# Altium schematic sheet power_side.SchDoc(power_side)
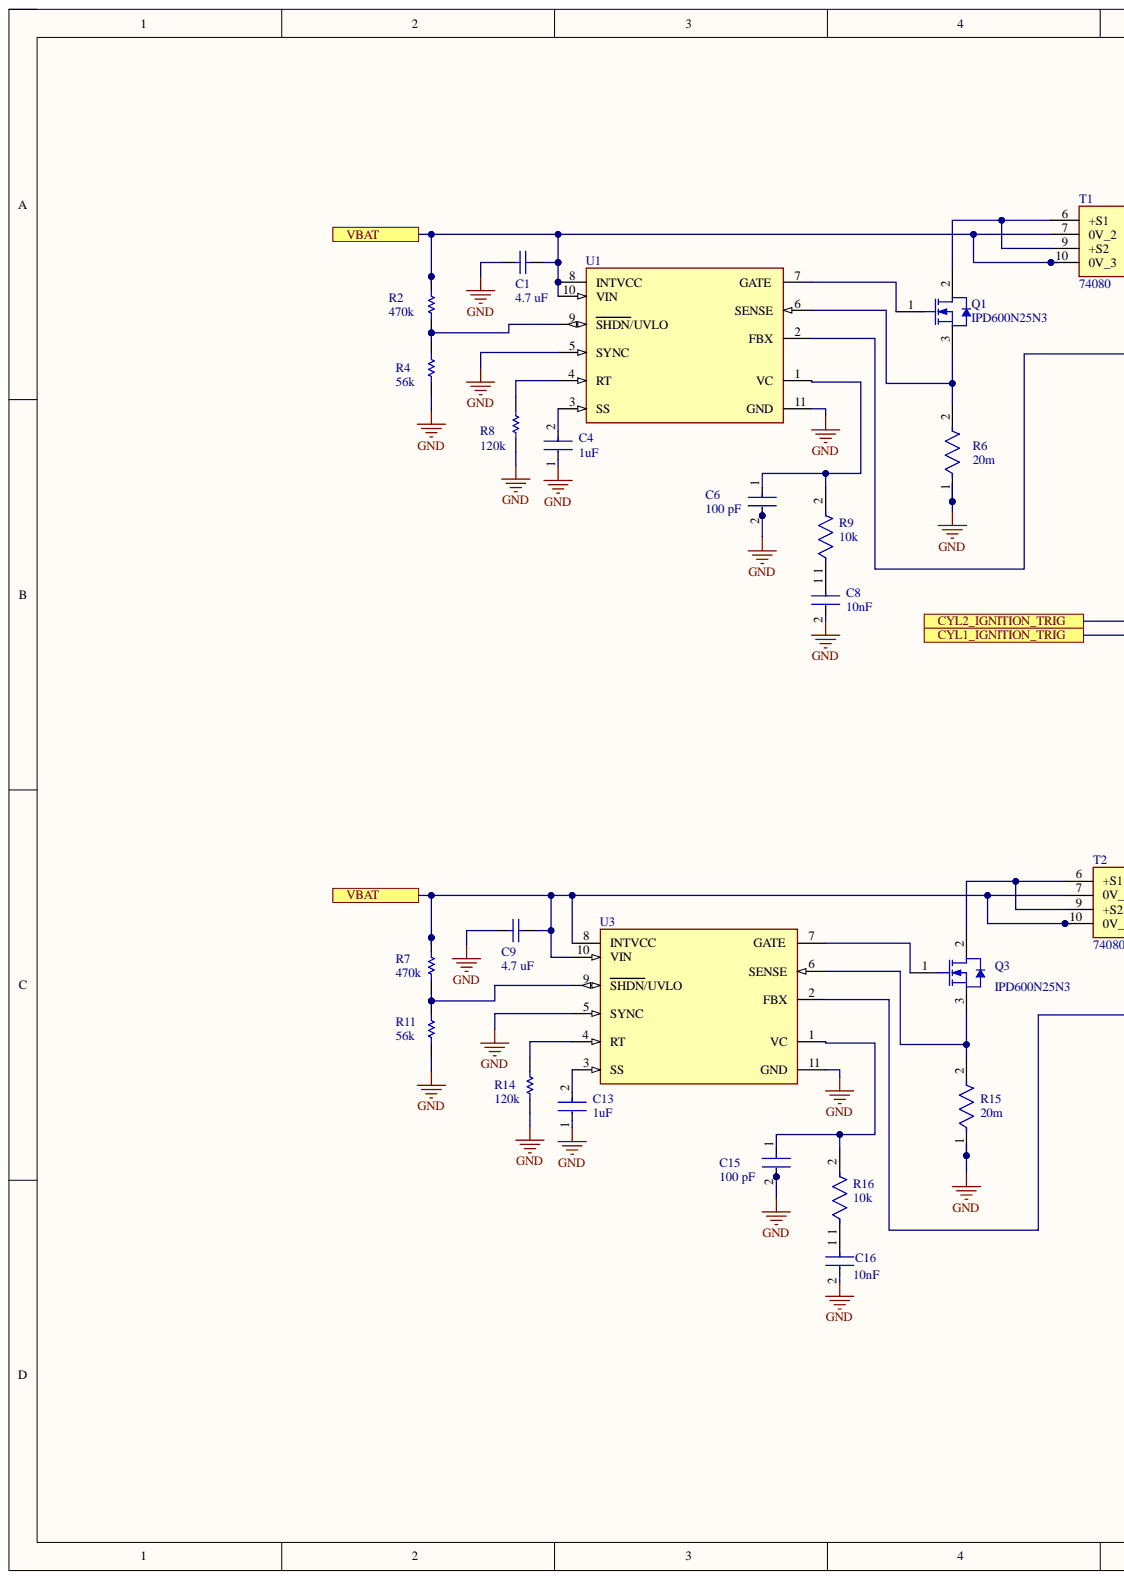
[diagram p0: power_side.SchDoc, left]
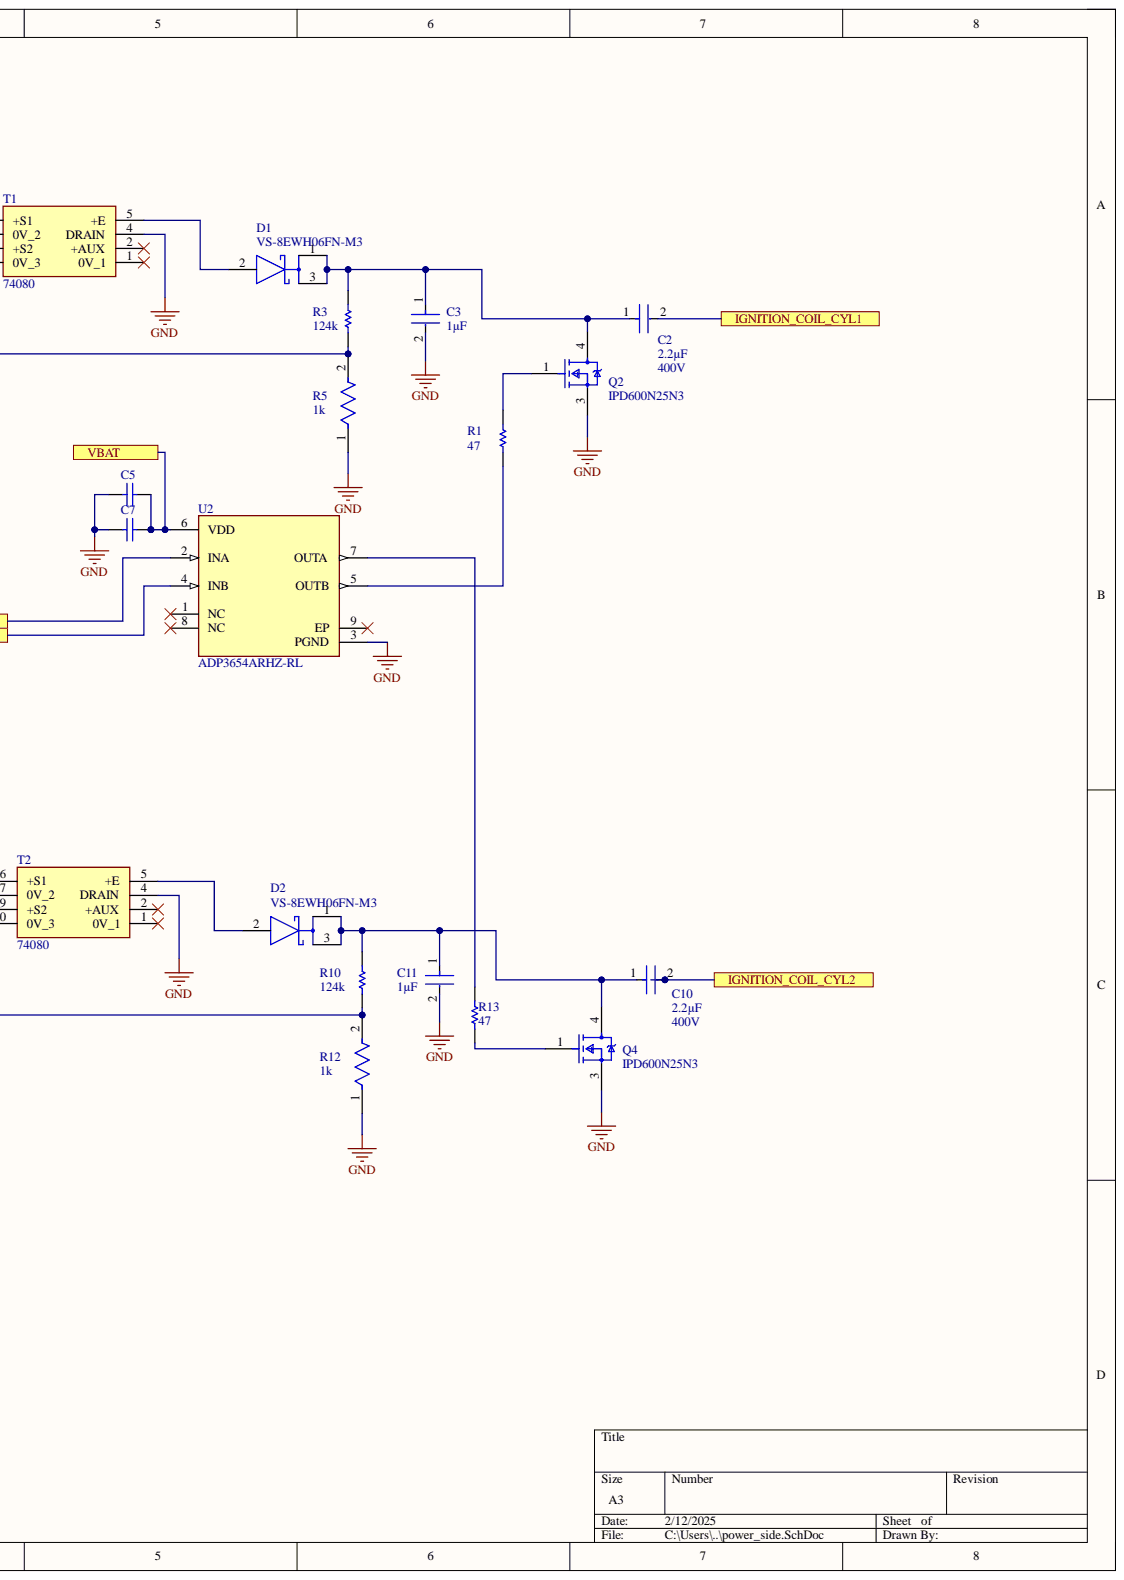
[diagram p1: power_side.SchDoc, right]

## Parts
C1  GRM21BR61C475KA88L  [CAPC2013X135X45LL10T25]  (value not drawn)
C2  Capacitance: 2.2µF  Voltage Rating (AC): 400V  [FP-BFC233820225-MFG]  (hidden comment: BFC233820225)
C3  Capacitance: 1µF  [FP-B32672Z4105K000-MFG]  (hidden comment: B32672Z4105K000)
C4  Capacitance: 1uF  [FP-C1608-080-0_1-IPC_B]  (hidden comment: C1608X5R1H105K080AB)
C5  06035C104KAT4A  [CAPC1608X90X35LL15T15]  (value not drawn)
C6  value 100 pF  Capacitance: 100 pF  [FP-CC0603-DA-MFG]  (hidden comment: CC0603KRX7R9BB101)
C7  C0603C475K8PACTU  [CAPC1608X87X35NL15T15]  (value not drawn)
C8  Capacitance: 10nF  [FP-0603-L_1_6_0_15-W_0_81-MFG]  (hidden comment: 06035C103KAT2A)
C9  Capacitance: 4.7 uF  [CAPC2013X135X45LL10T25]  (hidden comment: GRM21BR61C475KA88L)
C10  Capacitance: 2.2µF  Voltage Rating (AC): 400V  [FP-BFC233820225-MFG]  (hidden comment: BFC233820225)
C11  Capacitance: 1µF  [FP-B32672Z4105K000-MFG]  (hidden comment: B32672Z4105K000)
C13  Capacitance: 1uF  [FP-C1608-080-0_1-IPC_B]  (hidden comment: C1608X5R1H105K080AB)
C15  value 100 pF  Capacitance: 100 pF  [FP-CC0603-DA-MFG]  (hidden comment: CC0603KRX7R9BB101)
C16  Capacitance: 10nF  [FP-0603-L_1_6_0_15-W_0_81-MFG]  (hidden comment: 06035C103KAT2A)
D1  PN: VS-8EWH06FN-M3  [FP-TO-252-2_v2-MFG]  (hidden comment: C3D03060E)
D2  PN: VS-8EWH06FN-M3  [FP-TO-252-2_v2-MFG]  (hidden comment: C3D03060E)
Q1  IPD600N25N3  [INF-PG-TO252-3-11_A_L]
Q2  IPD600N25N3  [FP-PG-TO252-3-MFG]
Q3  IPD600N25N3  [INF-PG-TO252-3-11_A_L]
Q4  IPD600N25N3  [FP-PG-TO252-3-MFG]
R1  value 47  [RESC1609X50X30LL10T20]  (hidden comment: CRCW060347R0FKEA)
R2  value 470k  [RESC1608X55X25ML10T15]  (hidden comment: RC0603FR-07470KL)
R3  value 124k  [RESC1608X55X30LL15T15]  (hidden comment: ERJ-3EKF1243V)
R4  value 56k  [RESC1608X55X25LL10T15]  (hidden comment: RC0603FR-0756KL)
R5  value 1k  [FP-RC0603-0_55-IPC_C]  (hidden comment: RC0603FR-071KL)
R6  value 20m  [FP-CRA2512-MFG]  (hidden comment: CRA2512-FZ-R020ELF)
R7  value 470k  [RESC1608X55X25ML10T15]  (hidden comment: RC0603FR-07470KL)
R8  value 120k  [RESC1608X55X25NL10T15]  (hidden comment: RC0603FR-07120KL)
R9  Resistance: 10k  [FP-RC0603-0_55-IPC_C]  (hidden comment: RC0603FR-0710KL)
R10  value 124k  [RESC1608X55X30LL15T15]  (hidden comment: ERJ-3EKF1243V)
R11  Resistance: 56k  [RESC1608X55X25LL10T15]  (hidden comment: RC0603FR-0756KL)
R12  value 1k  [FP-RC0603-0_55-IPC_C]  (hidden comment: RC0603FR-071KL)
R13  value 47  [RESC1609X50X30LL10T20]  (hidden comment: CRCW060347R0FKEA)
R14  value 120k  [RESC1608X55X25NL10T15]  (hidden comment: RC0603FR-07120KL)
R15  value 20m  [FP-CRA2512-MFG]  (hidden comment: CRA2512-FZ-R020ELF)
R16  Resistance: 10k  [FP-RC0603-0_55-IPC_C]  (hidden comment: RC0603FR-0710KL)
T1  74080  [74080]
T2  74080  [74080]
U1  LT3758EDD#PBF  [FP-DD-10-05-08-1699-IPC_B]  (value not drawn)
U2  ADP3654ARHZ-RL  [FP-RH-8-1-IPC_C]
U3  LT3758EDD#PBF  [FP-DD-10-05-08-1699-IPC_B]  (value not drawn)

## Ports
CYL1_IGNITION_TRIG, CYL2_IGNITION_TRIG, IGNITION_COIL_CYL1, IGNITION_COIL_CYL2, VBAT

## Nets
GND: C1.1 C3.2 C4.1 C5.2 C6.2 C7.1 C8.2 C9.1 C11.2 C13.1 C15.2 C16.2 Q2.3 Q4.3 R4.1 R5.1 R6.1 R8.1 R11.1 R12.1 R14.1 R15.1 T1.4(DRAIN) T2.4(DRAIN) U1.5(SYNC) U1.11(GND) U2.3(PGND) U3.5(SYNC) U3.11(GND)
CYL1_IGNITION_TRIG: U2.4(INB) ; port CYL1_IGNITION_TRIG
CYL2_IGNITION_TRIG: U2.2(INA) ; port CYL2_IGNITION_TRIG
IGNITION_COIL_CYL1: C2.2 ; port IGNITION_COIL_CYL1
IGNITION_COIL_CYL2: C10.2 ; port IGNITION_COIL_CYL2
VBAT: C1.2 C5.1 C7.2 C9.2 R2.2 R7.2 T1.7(0V_2) T1.10(0V_3) T2.7(0V_2) T2.10(0V_3) U1.8(INTVCC) U1.10(VIN) U2.6(VDD) U3.8(INTVCC) U3.10(VIN) ; port VBAT
NetC2_1: C2.1 C3.1 D1.1 D1.3 Q2.4 R3.2
NetC4_2: C4.2 U1.3(SS)
NetC6_1: C6.1 R9.2 U1.1(VC)
NetC8_1: C8.1 R9.1
NetC10_1: C10.1 C11.1 D2.1 D2.3 Q4.4 R10.2
NetC13_2: C13.2 U3.3(SS)
NetC15_1: C15.1 R16.2 U3.1(VC)
NetC16_1: C16.1 R16.1
NetD1_2: D1.2 T1.5(+E)
NetD2_2: D2.2 T2.5(+E)
NetQ1_1: Q1.1 U1.7(GATE)
NetQ1_2: Q1.2 T1.6(+S1) T1.9(+S2)
NetQ1_3: Q1.3 R6.2 U1.6(SENSE)
NetQ2_1: Q2.1 R1.2
NetQ3_1: Q3.1 U3.7(GATE)
NetQ3_2: Q3.2 T2.6(+S1) T2.9(+S2)
NetQ3_3: Q3.3 R15.2 U3.6(SENSE)
NetQ4_1: Q4.1 R13.1
NetR1_1: R1.1 U2.5(OUTB)
NetR2_1: R2.1 R4.2 U1.9(SHDN/UVLO)
NetR3_1: R3.1 R5.2 U1.2(FBX)
NetR7_1: R7.1 R11.2 U3.9(SHDN/UVLO)
NetR8_2: R8.2 U1.4(RT)
NetR10_1: R10.1 R12.2 U3.2(FBX)
NetR13_2: R13.2 U2.7(OUTA)
NetR14_2: R14.2 U3.4(RT)

Not connected: T1 1(0V_1) 2(+AUX); T2 1(0V_1) 2(+AUX); U2 1(NC) 8(NC) 9(EP)

## Library data of the parts
C1 = CMP-1662-00015-2: Chip Capacitor, 4.7 uF, +/- 10%, 16 V, -55 to 85 degC, 0805 (2012 Metric), RoHS, Tape and Reel; Capacitance: 4.7 uF; Case\/Package: 0805; Type (Capacitors): X5R; Voltage Rating: 16 V; Case Code (Imperial): 0805; Case Code (Metric): 2012; Component Kind: Standard; ComponentLink1Description: Manufacturer URL; ComponentLink1URL: http://www.murata.com/; ComponentLink2Description: Datasheet; ComponentLink2URL: http://search.murata.co.jp/Ceramy/image/img/A01X/G101/ENG/GRM21BR61C475KA88-01.pdf; DatasheetVersion: 03/2016; Height: 1.25 mm; Length: 2 mm; Library Name: Altium Content Vault; Max Operating Temperature: 85 degC; Min Operating Temperature: -55 degC; Mount: Surface Mount; Mounting Technology: Surface Mount; Package Quantity: 3000; PackageDescription: 2-Pin Surface Mount Device, Body 2 x 1.25 mm; PackageReference: 0805; Packaging: Tape and Reel; PartNumber: GRM21BR61C475KA88L; Pins: 2; Radiation Hardening: No; REACH SVHC: No SVHC; RoHSCompliant: true; Tolerance: 10%; Voltage Rating (DC): 16 V; Width: 1.25 mm
C2 C10 = CMP-00030-39758189-1: BFC233820225 Film Capacitor, Polypropylene, 800V, 20% +Tol, 20% -Tol, 0.22uF, Through Hole Mount; Dielectric: PP; Insulation Resistance: 11GΩ; Lead Diameter: 800µm; Voltage Rating: 630V; Case/Package: Radial; Component Kind: Standard; Contact Plating: Tin; Depth: 15mm; Diameter: 18mm; Dielectric Material: PP; Height: 28mm; Height - Seated (Max): 24.9936mm; Lead Free: Lead Free; Lead Pitch: 27.5mm; Length: 31.5mm; Library Name: Altium Content Vault; Max Operating Temperature: 110°C; Min Operating Temperature: -55°C; Mount: Through Hole; NexarPartId: 39758189; Number of Pins: 2; Packaging: Bulk; Radiation Hardening: No; REACH SVHC: Unknown; RoHS: Compliant; Schedule B: 8532250020, 8532250020; Termination: Radial; Tolerance: 20%; Voltage Rating (DC): 630V; Width: 15.0114mm
C3 C11 = CMP-00131-19048049-1: B32672Z4105K000 Film Capacitor, Polypropylene, 450V, 10% +Tol, 10% -Tol, 1uF, Through Hole Mount, 7143; Dielectric: PP; Lead Diameter: 800µm; Voltage Rating (AC): 220V; Case/Package: Radial; Component Kind: Standard; Depth: 11mm; Dielectric Material: Polypropylene; Features: General Purpose; Height: 18.5mm; Height - Seated (Max): 18.4912mm; Lead Pitch: 15mm; Length: 18mm; Library Name: Altium Content Vault; Lifecycle Status: Production; Max Length: 18mm; Max Operating Temperature: 125°C; Max Width: 11mm; Min Operating Temperature: -55°C; Mount: Through Hole; NexarPartId: 19048049; Packaging: Bulk; Radiation Hardening: No; REACH SVHC: No SVHC; RoHS: Compliant; Termination: PC Pins; Tolerance: 10%; Voltage Rating: 450V; Voltage Rating (DC): 450V; Width: 10.9982mm
C4 C13 = CMP-2000-06025-2: Multilayer Ceramic Capacitors 1μF ±10% 50V X5R SMD 0603; Case Code (Imperial): 0603; Case Code (Metric): 1608; Case/Package: 0603; Component Kind: Standard; Construction: Flat; Depth: 0.8mm; Dielectric: X5R; Dielectric Material: Ceramic; Height: 0.8mm; Lead Free: Lead Free; Length: 1.6mm; Library Name: Altium Content Vault; Max Operating Temperature: 85°C; Min Operating Temperature: -55°C; Mount: Surface Mount; Number of Pins: 2; Package Quantity: 1; Packaging: Tape and Reel; Radiation Hardening: No; REACH SVHC: No SVHC; Resistor Type: Ceramic; RoHS Compliant: Yes; Series: C; Termination: SMD/SMT; Thickness: 0.035inch; Tolerance: 10%; Voltage: 50V; Voltage Rating: 50V; Voltage Rating (DC): 50V; Weight: 7.1E-05oz; Width: 0.031inch
C5 = CMP-2006-04390-1: Manufacturer: AVX Interconnect / Elco; Component Kind: Standard; Library Name: Altium Content Vault; Part Number: 06035C104KAT4A
C6 C15 = CMP-03422-000747-1: CAP CER 100PF 50V X7R 0603; Manufacturer: YAGEO; Material: Ceramic; Temperature Coefficient: 15%; Tolerance: 0.1; Automotive: No; Category: Cap; Component Kind: Standard; ComponentLink2Description: Datasheet; ComponentLink2URL: http://www.yageo.com/documents/recent/UPY-GPHC_X7R_6.3V-to-50V_18.pdf; Device Class L1: Passive Components; Device Class L2: Capacitors; Device Class L3: Ceramic Capacitors; Digikey Description: CAP CER 100PF 50V X7R 0603; Footprint Url: http://www.yageo.com/exep/pages/download/literatures/UPY-C_GEN_24.pdf; Height: 0.9mm; Lead Free: Yes; Library Name: Altium Content Vault; Manufacturer Part Number: CC0603KRX7R9BB101; Mouser Description: Multilayer Ceramic Capacitor, CC Series, 100 pF, - 10%, X7R, 50 V, 0603 [1608 Metric]; Octopart: https://octopart.com/cc0603krx7r9bb101-yageo-42334526; Package: CC0603-DA; RoHS: TRUE; Temperature: -55°C to +125°C; Temperature Characteristic: X7R; Temperature Range High: +125°C; Temperature Range Low: -55°C; Voltage: 50 V; Voltage Rating: 50 V
C7 = CMP-2006-03238-1: Manufacturer: Kemet; Component Kind: Standard; Library Name: Altium Content Vault; Part Number: C0603C475K8PACTU
C8 C16 = CMP-2006-04374-2: General Purpose Ceramic Capacitor, 0603, 10nF, 10%, X7R, 15%, 50V; Dissipation Factor: 2.5%; Insulation Resistance: 100GR; Case Code (Imperial): 0603; Case Code (Metric): 1608; Case/Package: 0603; Component Kind: Standard; Contact Plating: Tin; Depth: 0.81mm; Dielectric: X7R; Dielectric Material: Ceramic; Height: 0.9mm; Lead Free: Lead Free; Length: 1.6mm; Library Name: Altium Content Vault; Max Operating Temperature: 125°C; Min Operating Temperature: -55°C; Mount: Surface Mount; Number of Pins: 2; Package Quantity: 1; Packaging: Tape and Reel; Radiation Hardening: No; REACH SVHC: No SVHC; Termination: SMD/SMT; Thickness: 0.035inch; Tolerance: 10%; Voltage: 50V; Voltage Rating: 50V; Voltage Rating (DC): 50V; Width: 0.032inch
C9 = CMP-1662-00015-2: Chip Capacitor, 4.7 uF, +/- 10%, 16 V, -55 to 85 degC, 0805 (2012 Metric), RoHS, Tape and Reel; Case\/Package: 0805; Type (Capacitors): X5R; Voltage Rating: 16 V; Case Code (Imperial): 0805; Case Code (Metric): 2012; Component Kind: Standard; ComponentLink1Description: Manufacturer URL; ComponentLink1URL: http://www.murata.com/; ComponentLink2Description: Datasheet; ComponentLink2URL: http://search.murata.co.jp/Ceramy/image/img/A01X/G101/ENG/GRM21BR61C475KA88-01.pdf; DatasheetVersion: 03/2016; Height: 1.25 mm; Length: 2 mm; Library Name: Altium Content Vault; Max Operating Temperature: 85 degC; Min Operating Temperature: -55 degC; Mount: Surface Mount; Mounting Technology: Surface Mount; Package Quantity: 3000; PackageDescription: 2-Pin Surface Mount Device, Body 2 x 1.25 mm; PackageReference: 0805; Packaging: Tape and Reel; PartNumber: GRM21BR61C475KA88L; Pins: 2; Radiation Hardening: No; REACH SVHC: No SVHC; RoHSCompliant: true; Tolerance: 10%; Voltage Rating (DC): 16 V; Width: 1.25 mm
D1 D2 = CMP-240925-000041-3: DIODE SCHOTTKY 600V 3A TO252-2; Average Rectified Current: 11A; Element Configuration: Single; Forward Voltage: 1.7V; Max Forward Surge Current (Ifsm): 100A; Max Power Dissipation: 53W; Max Reverse Current: 100uA; Max Reverse Leakage Current: 50uA; Reverse Recovery Time: 0ns; Case/Package: TO-252; Component Kind: Standard; Forward Current: 3A; Height: 2.388mm; Length: 7.34mm; Library Name: Altium Content Vault; Max Operating Temperature: 175°C; Max Repetitive Reverse Voltage (Vrrm): 600V; Max Reverse Voltage (DC): 600V; Max Surge Current: 100A; Min Operating Temperature: -55°C; Mount: Surface Mount; Number of Pins: 2; Package Quantity: 75; Packaging: Rail/Tube; Peak Non-Repetitive Surge Current: 100A; Radiation Hardening: No; RoHS Compliant: Yes; Series: Z-Rec®; Termination: Through Hole; Width: 7.518mm
Q1 Q3 = CMP-1091-00172-1: N-Channel OptiMOS 3 Power-Transistor, 60 V VDS, 90 A ID, -55 to 175 degC, PG-TO252-3-11, Reel, Green; Manufacturer: Infineon; PartNumber: IPD035N06L3 G; Green: TRUE; ID (max): 90Â A; IDpuls (max): 360Â A; Polarity: N; Ptot (max): 167Â W; QG: 59Â nC; RDS (on) (max): 3.5Â mOhm; RDS (on) (max) (@4.5V): 5.5Â mOhm; Rth: 0.9Â K/W; RthJA (max): 62 K/W; VDS (max): 60Â V; VGS(th) (max): 2.2Â V; VGS(th) (min): 1.2Â V; Component Kind: Standard; ComponentLink1Description: Manufacturer URL; ComponentLink1URL: http://www.infineon.com/; ComponentLink2Description: Datasheet; ComponentLink2URL: http://www.infineon.com/dgdl/IPD035N06L3_Rev2.3.pdf?folderId=db3a304313b8b5a60113cee8763b02d7&fileId=db3a30431b3e89eb011b4558ed7f7d14; ComponentLink3Description: Package Specification; ComponentLink3URL: http://www.infineon.com/dgdl/IPD160N04L_rev1.0.pdf?folderId=db3a3043163797a6011643468e7505a4&fileId=db3a3043163797a60116436401cf05cf; DatasheetVersion: Rev. 2.3; Halogen-free: TRUE; Library Name: Altium Content Vault; Mounting Technology: Surface Mount; PackageDescription: 3-Pin Plastic (Green) Transistor Single Outline Package, 6.57 x 6.1 mm Body, 2.29 mm Pitch; PackageReference: PG-TO252-3-11; PackageVersion: Rev. 02, 03/2007; Packing: Reel; RthJC (max): 0.9Â K/W
Q2 Q4 = CMP-32901-000143-1: MOSFET N-CH 30V 161A DPAK; Manufacturer: Infineon; Manufacturer Part Number: IRLR7843TRPBF; Depletion Mode: False; Drain To Source Resistance: 3.2mΩ; Gate Charge At Vgs: 34nC @ 4.5V; Gate To Source Voltage: 20V; Input Capacitace At Vds: 4380pF @ 15V; Max Forward Diode Voltage: 1V; Max Junction Temp: +175°C; Number Of Channels: 1; Power Dissipation: 140W; Pulse Drain Current: 620A; Reverse Recovery Charge: 36nC; Reverse Recovery Time: 39ns; Rthja Max: 50°C/W; Threshold Vgs Max: 2.3V; Threshold Vgs Min: 1.4V; Turn Off Delay Time: 34ns; Turn On Delay Time: 25ns; Automotive: No; Category: Trans; Component Kind: Standard; ComponentLink2Description: Datasheet; ComponentLink2URL: https://www.infineon.com/dgdl/irlr7843pbf.pdf?fileId=5546d462533600a40153566de53526d8; Continuous Drain Current: 161A; Device Class L1: Discrete Semiconductors; Device Class L2: Transistors; Device Class L3: MOSFETs; Digikey Description: MOSFET N-CH 30V 161A DPAK; Drain To Source Breakdown Voltage: 30V; Drain To Source Voltage: 30V; Footprint Url: https://www.infineon.com/cms/en/product/packages/PG-TO252/PG-TO252-3-901/; Height: 2.52mm; Lead Free: Yes; Library Name: Altium Content Vault; Number Of N Channels: 1; Octopart: https://octopart.com/irlr7843trpbf-infineon-65875062; Package: PG-TO252-3; RoHS: TRUE; Temperature: -55°C to +175°C; Temperature Range High: +175°C; Temperature Range Low: -55°C
R1 R13 = CMP-2000-03383-1: Manufacturer: Vishay Dale; Component Kind: Standard; Library Name: Altium Content Vault; Part Number: CRCW060347R0FKEA
R2 R7 = CMP-1659-00049-1: Chip Resistor, 470 KOhm, +/- 1%, 0.1 W, -55 to 155 degC, 0603 (1608 Metric), RoHS, Tape and Reel; Component Kind: Standard; ComponentLink1Description: Manufacturer URL; ComponentLink1URL: http://www.yageo.com/; ComponentLink2Description: Datasheet; ComponentLink2URL: http://www.yageo.com/documents/recent/PYu-RC_Group_51_RoHS_L_04.pdf; DatasheetVersion: Rev. 4, 02/2016; Library Name: Altium Content Vault; PackageDescription: 2-Pin Surface Mount Device, Body 1.6 x 0.8 mm; PackageReference: 0603
R3 R10 = CMP-2000-00225-1: Manufacturer: Panasonic; Component Kind: Standard; Library Name: Altium Content Vault; Part Number: ERJ-3EKF1243V
R4 = CMP-2000-06943-1: Chip Resistor, 56 KOhm, +/- 1%, 0.1 W, -55 to 155 degC, 0603 (1608 Metric), RoHS, Tape and Reel; Brand: Yageo; Power Rating: 100mW; Resistance: 56kOhms; Temperature Coefficient: 100PPM/C; Unit Weight: 0.000071oz; Voltage Rating: 75V; Case Code - in: 0603; Case Code - mm: 1608; Component Kind: Standard; Datasheet URL: http://www.yageo.com/documents/recent/PYu-RC_Group_51_RoHS_L_7.pdf; Datasheet Version: Rev. 7, 05/2017; Height: 0.45mm; Length: 1.6mm; Library Name: Altium Content Vault; Manufacturer: Yageo; Manufacturer URL: http://www.yageo.com/; Mounting Technology: SurfaceMount; Operating Temperature Range: -55Cto+155C; Package / Case: 0603(1608metric); Package Description: 2-Pin Surface Mount Device, Body 1.6 x 0.8 mm; Package Reference: 0603; Packaging: Reel; Product: ThickFilmResistorsGeneralPurpose; Product Category: ThickFilmResistors; RoHS: True; Series: RC; Termination Style: SMD/SMT; Tolerance: 1%; Type: GeneralPurposeChipResistorSurfaceMount; Width: 0.8mm
R5 R12 = CMP-1659-00009-5: RES 1K OHM 1% 1/10W 0603; Max Power Dissipation: 100mW; Resistance: 1kR; Temperature Coefficient: 100ppm/°C; Voltage Rating: 50V; Case Code (Imperial): 0603; Case Code (Metric): 1608; Case/Package: 0603; Component Kind: Standard; Construction: Rectangular; Contact Plating: Tin; Depth: 0.8mm; Features: Moisture Resistant; Height: 0.45mm; Lead Free: Lead Free; Length: 1.6mm; Library Name: Altium Content Vault; Max Operating Temperature: 155°C; Military Standard: Not; Min Operating Temperature: -55°C; Number of Terminations: 2; Packaging: Tape and Reel; Power Rating: 100mW; Radiation Hardening: No; REACH SVHC: No SVHC; Resistance Tolerance: 1%; Resistor Type: Thick Film; RoHS Compliant: Yes; Series: RC; Size Code: 0603; Technology: THICK FILM; Termination: SMD/SMT; Tolerance: 1%
R6 R15 = CMP-07231-006693-1: RES 0.02 OHM 1% 3W 2512; Component Kind: Standard; Library Name: Altium Content Vault
R8 R14 = CMP-1659-00024-2: Chip Resistor, 120 KOhm, +/- 1%, 100 mW, -55 to 155 degC, 0603 (1608 Metric), RoHS, Tape and Reel; Capacitance: 22 pF; Case\Package: Radial; Tolerance: 10%; Type (Capacitors): C0G; Voltage Rating: 100 V; Component Kind: Standard; ComponentLink1Description: Manufacturer URL; ComponentLink1URL: http://www.yageo.com/; ComponentLink2Description: Datasheet; ComponentLink2URL: http://www.yageo.com/documents/recent/PYu-RC_Group_51_RoHS_L_04.pdf; DatasheetVersion: Rev. 4, 02/2016; Height: 2.54 mm; Lead Pitch: 2.54 mm; Length: 5.33 mm; Library Name: Altium Content Vault; Max Operating Temperature: 125 degC; Min Operating Temperature: -55 degC; Mount: Through Hole; Package Quantity: 500; PackageDescription: 2-Pin Surface Mount Device, Body 1.6 x 0.8 mm; PackageReference: 0603; Packaging: Bulk; Radiation Hardening: No; RoHSCompliant: true; Voltage Rating (DC): 100 V; Width: 3.81 mm
R9 R16 = CMP-2100-03664-2: RES 10K OHM 1% 1/10W 0603; Max Power Dissipation: 100mW; Temperature Coefficient: 100ppm/°C; Voltage Rating: 75V; Voltage Rating (DC): 50V; Case Code (Imperial): 0603; Case Code (Metric): 1608; Case/Package: 0603; Component Kind: Standard; Construction: Rectangular; Depth: 0.8mm; Features: Moisture Resistant; Height: 0.45mm; Lead Free: Lead Free; Lead Pitch: 0.3mm; Length: 1.6mm; Library Name: Altium Content Vault; Max Operating Temperature: 155°C; Military Standard: Not; Min Operating Temperature: -55°C; Number of Pins: 2; Number of Terminations: 2; Packaging: Tape and Reel; Power Rating: 100mW; Resistance Tolerance: 1%; Resistor Type: Thick Film; RoHS Compliant: Yes; Series: RC; Size Code: 0603; Termination: SMD/SMT; Tolerance: 1%; Weight: 7.1E-05oz; Width: 0.8mm
R11 = CMP-2000-06943-1: Chip Resistor, 56 KOhm, +/- 1%, 0.1 W, -55 to 155 degC, 0603 (1608 Metric), RoHS, Tape and Reel; Brand: Yageo; Power Rating: 100mW; Temperature Coefficient: 100PPM/C; Unit Weight: 0.000071oz; Voltage Rating: 75V; Case Code - in: 0603; Case Code - mm: 1608; Component Kind: Standard; Datasheet URL: http://www.yageo.com/documents/recent/PYu-RC_Group_51_RoHS_L_7.pdf; Datasheet Version: Rev. 7, 05/2017; Height: 0.45mm; Length: 1.6mm; Library Name: Altium Content Vault; Manufacturer: Yageo; Manufacturer URL: http://www.yageo.com/; Mounting Technology: SurfaceMount; Operating Temperature Range: -55Cto+155C; Package / Case: 0603(1608metric); Package Description: 2-Pin Surface Mount Device, Body 1.6 x 0.8 mm; Package Reference: 0603; Packaging: Reel; Product: ThickFilmResistorsGeneralPurpose; Product Category: ThickFilmResistors; RoHS: True; Series: RC; Termination Style: SMD/SMT; Tolerance: 1%; Type: GeneralPurposeChipResistorSurfaceMount; Width: 0.8mm
T1 T2 = 74080: Transformer; Manufacturer_Name: MYRRA; Component Kind: Standard; Datasheet Link: https://www.tme.com/Document/74b1c6fdfa5c80edd28be424718b4d1b/74080.pdf; Height: 18mm; Manufacturer_Part_Number: 74080
U1 U3 = CMP-09139-000585-1: IC REG CTRLR MULT TOPOLOGY 10DFN; Max Frequency: 1MHz; Max Input Voltage: 100V; Max Output Current: 1.2A; Max Output Voltage: 12V; Min Input Voltage: 5.5V; Number of Outputs: 1; Topology: Boost; Case/Package: DFN; Component Kind: Standard; Height: 0.7mm; Length: 3mm; Library Name: Altium Content Vault; Max Operating Temperature: 125°C; Max Supply Voltage: 100V; Min Operating Temperature: -40°C; Min Supply Voltage: 5.5V; Mount: Surface Mount; Number of Pins: 10; Output Voltage: 100V; Package Quantity: 121; Packaging: Rail/Tube; REACH SVHC: No SVHC; RoHS Compliant: Yes; Series: -; Switching Frequency: 1MHz; Width: 3mm
U2 = CMP-09133-000023-1: IC GATE DRVR LOW-SIDE 8MINISOIC; Channel Type: Independent; Fall Time: 25ns; Max Input Voltage: 18V; Max Output Current: 4A; Max Supply Current: 3mA; Min Input Voltage: 4.5V; Number of Drivers: 2; Propagation Delay: 35ns; Rise Time: 14ns; Case/Package: MSOP; Component Kind: Standard; Lead Free: Contains Lead; Library Name: Altium Content Vault; Max Operating Temperature: 125°C; Max Supply Voltage: 18V; Min Operating Temperature: -40°C; Min Supply Voltage: 4.5V; Mount: Surface Mount; Nominal Supply Current: 3mA; Number of Outputs: 2; Number of Pins: 8; Output Current: 4A; Package Quantity: 3000; Packaging: Tape and Reel; RoHS Compliant: Yes; Series: -; Supply Current: 3mA; Turn-On Delay Time: 35ns
